# Altium schematic sheet [6]-CHARGER.SchDoc([6]-CHARGER)
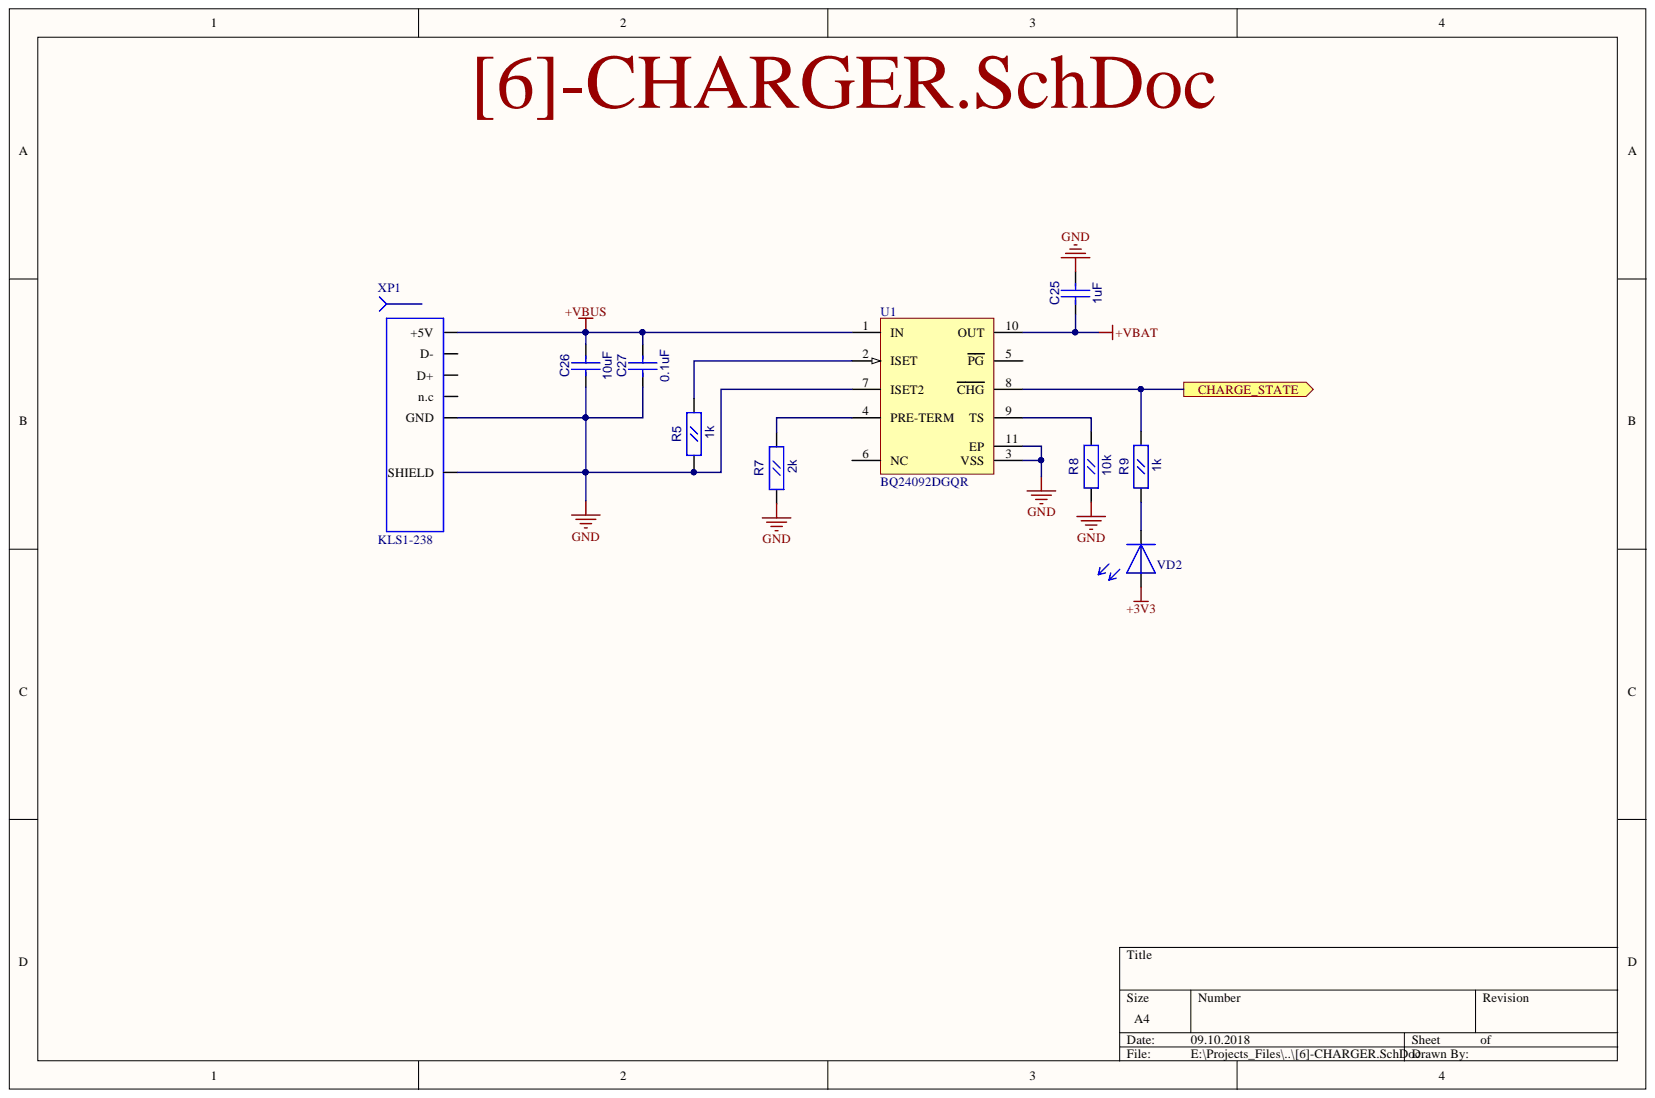
[diagram p0: [6]-CHARGER.SchDoc]

## Parts
C25  1uF  [CC0603]
C26  10uF  [CC0805]
C27  0.1uF  [CC0603]
R5  1k  [RC0603]
R7  2k  [RC0603]
R8  10k  [RC0603]
R9  1k  [RC0603]
U1  BQ24092DGQR  [DGQ10-1675X1445TP_N]
VD2  LED_0805  [LED0805]  (value not drawn)
XP1  KLS1-238  [KLS1-238]

## Ports
CHARGE_STATE

## Nets
+3V3: VD2.1
+VBAT: C25.1 U1.10(OUT)
+VBUS: C26.2 C27.2 U1.1(IN) XP1.1(+5V)
GND: C25.2 C26.1 C27.1 R5.1 R7.1 R8.1 U1.3(VSS) U1.7(ISET2) U1.11(EP) XP1.5(GND) XP1.6(SHIELD)
CHARGE_STATE: R9.2 U1.8(CHG) ; port CHARGE_STATE
NetR5_2: R5.2 U1.2(ISET)
NetR7_2: R7.2 U1.4(PRE-TERM)
NetR8_2: R8.2 U1.9(TS)
NetR9_1: R9.1 VD2.2

Not connected: U1 5(PG) 6(NC); XP1 2(D-) 3(D+) 4(n.c)

## Library data of the parts
C25 C27 = CC0603: Description: CC0603; Component Kind: Standard; Library Name: gprs_modem_2.SchLib
  C25: Value: 1uF
  C27: Value: 0.1uF
C26 = CC0805: Value: 10uF; Description: CC0805; Component Kind: Standard; Library Name: gprs_modem_2.SchLib
R5 R7-R9 = RC0603: Description: RC0603; Component Kind: Standard; Library Name: gprs_modem_2.SchLib
  R5 R9: Value: 1k
  R7: Value: 2k
  R8: Value: 10k
U1 = BQ24092DGQR: 1 A, Single-Input, Single-Cell Li-Ion Battery Charger with Operation Over JEITA and 10 k ohm NTC, 4.5 V, -40 to 150 degC, 10-pin MSOP-PowerPAD (DGQ), Green (RoHS & no Sb/Br); Manufacturer: Texas Instruments; Vin(Min)(V): 4.5; SubFamily: Battery Charge Management; Over Voltage Protection: N; Supplier Part Number 3: 595-BQ24092DGQR; Supplier Part Number 2: 79R7874; Supplier Part Number 1: BQ24092DGQR-ND; Supplier 3: Mouser; Supplier 2: Newark; Supplier 1: Digi-Key; PartNumber: BQ24092DGQR; PackageVersion: revF, Nov-2011; PackageReference: DGQ10-1675X1445TP; PackageDescription: 10-Pin PowerPAD Plastic Small Outline 0.5 mm Pitch, Exposed Pad; NiMH: N; NiCd: N; Mounting Technology: Surface Mount; Library Name: TI Power Management Battery Management.IntLib; Li-Polymer: N; Li-Ion: N; Lead Acid: N; Eco-Plan: Green (RoHS & no Sb/Br); DatasheetVersion: SLUS968C; ComponentLink1URL: http://www.ti.com/general/docs/lit/getliterature.tsp?genericPartNumber=BQ24092&fileType=pdf; ComponentLink1Description: Datasheet; Component Kind: Standard; Code_JEDEC: MO-187-BA-T
XP1 = MicroUSB KLS1-238: Value: KLS1-238; Component Kind: Standard; Library Name: gprs_modem_2.SchLib
VD2 = LED_0805: Value: LED_0805; Component Kind: Standard; Library Name: fizik_lib.SchLib
